# Altium schematic sheet Sensing_IC_Plus.SchDoc(Sensing_IC_Plus)
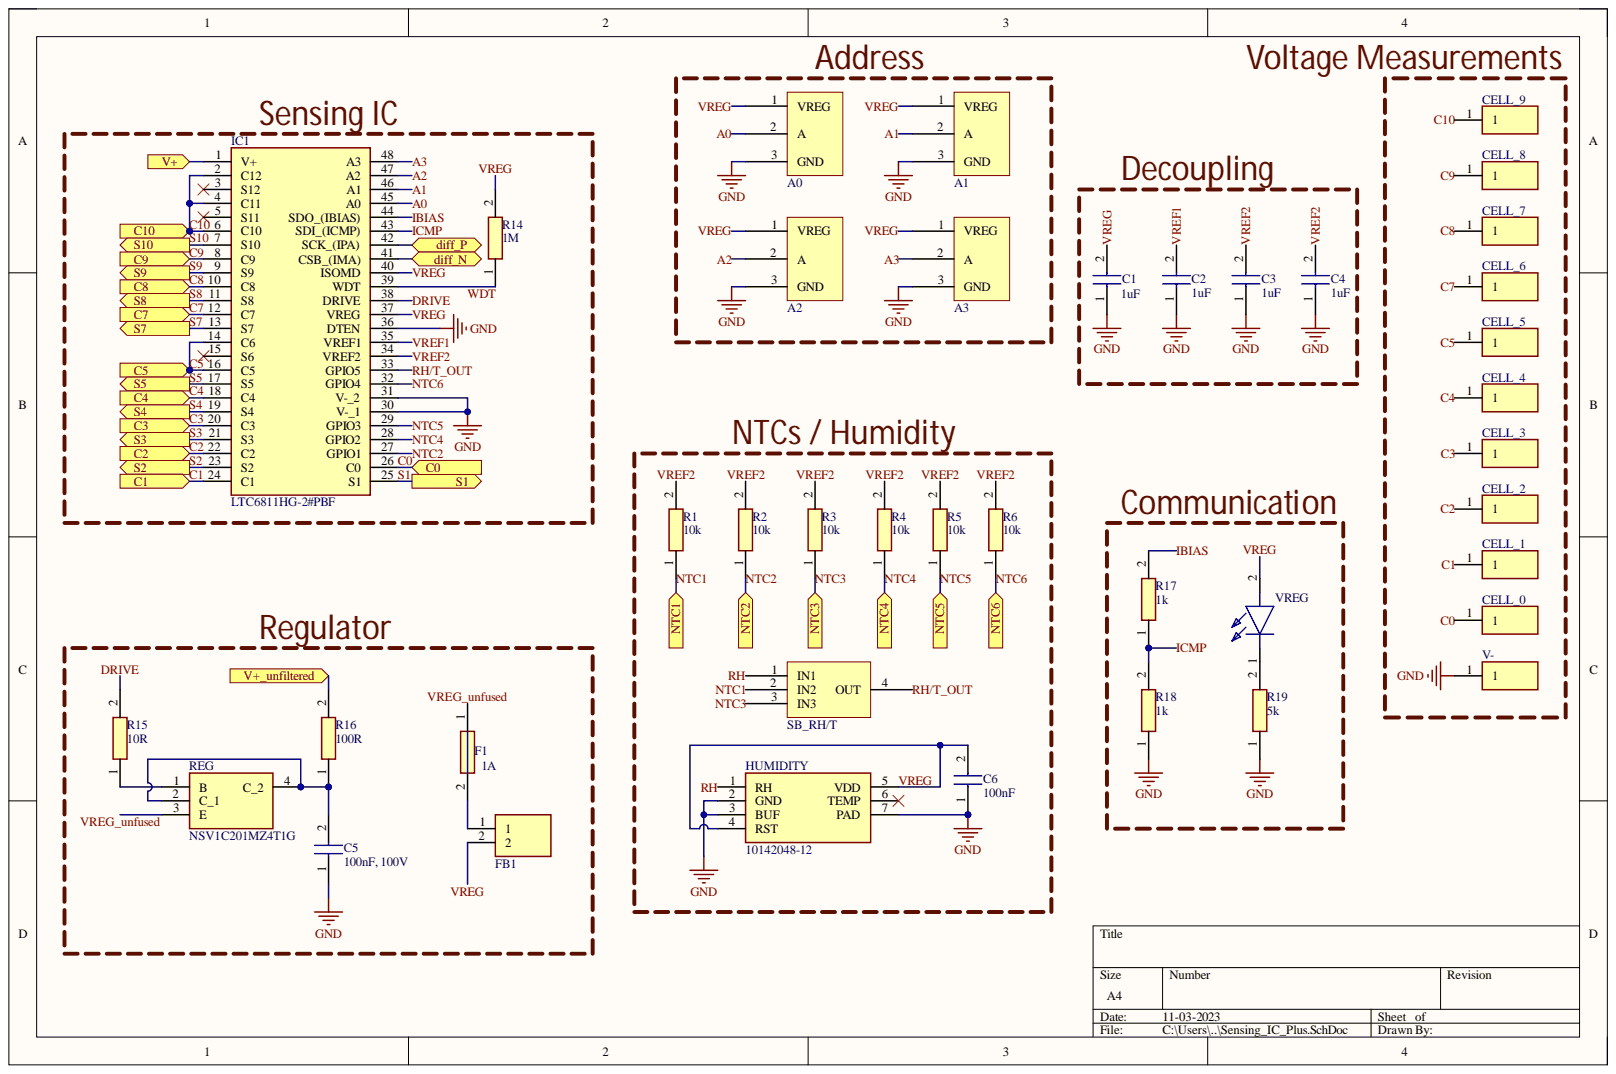
[diagram p0: Sensing_IC_Plus.SchDoc]

## Parts
*1  SB_RH/T  [RESC_1610X06N]  (part C)
A0  A0  [0R Resistor 0402]  (part D)
A1  A1  [0R Resistor 0402]  (part D)
A2  A2  [0R Resistor 0402]  (part D)
A3  A3  [0R Resistor 0402]  (part D)
C1  1uF  [CAPC1005X56N]
C2  1uF  [CAPC1005X56N]
C3  1uF  [CAPC1608X90N]
C4  1uF  [CAPC1608X90N]
C5  100nF, 100V  [CAPC1608X90N]
C6  100nF  [CAPC1608X90N]
CELL_0  050_LT_B2  [050LTB2]  (value not drawn)
CELL_1  050_LT_B2  [050LTB2]  (value not drawn)
CELL_2  050_LT_B2  [050LTB2]  (value not drawn)
CELL_3  050_LT_B2  [050LTB2]  (value not drawn)
CELL_4  050_LT_B2  [050LTB2]  (value not drawn)
CELL_5  050_LT_B2  [050LTB2]  (value not drawn)
CELL_6  050_LT_B2  [050LTB2]  (value not drawn)
CELL_7  050_LT_B2  [050LTB2]  (value not drawn)
CELL_8  050_LT_B2  [050LTB2]  (value not drawn)
CELL_9  050_LT_B2  [050LTB2]  (value not drawn)
F1  1A  [FUSC1608X30N]
FB1  MMZ1608SHE102ATD25  [BEADC1608X95N]  (value not drawn)
HUMIDITY  10142048-12  [1014204812]
IC1  LTC6811HG-2#PBF  [SOP50P780X200-48N]
R1  10k  [RESC1608X55N]
R2  10k  [RESC1608X55N]
R3  10k  [RESC1608X55N]
R4  10k  [RESC1608X55N]
R5  10k  [RESC1608X55N]
R6  10k  [RESC1608X55N]
R14  1M  [RESC1005X35N]
R15  10R  [RESC1608X55N]
R16  100R  [RESC1608X55N]
R17  1k  [RESC1608X55N]
R18  1k  [RESC1608X55N]
R19  5k  [RESC1608X55N]
REG  NSV1C201MZ4T1G  [SOT230P700X175-4N]
V-  050_LT_B2  [050LTB2]  (value not drawn)
VREG  LTST-C170KRKT  [LEDC2012X120N]  (value not drawn)

## Ports
C0, C1, C2, C3, C4, C5, C7, C8, C9, C10, diff_N, diff_P, NTC1, NTC2, NTC3, NTC4, NTC5, NTC6, S1, S2, S3, S4, S5, S7, S8, S9, S10, V+, V+_unfiltered

## Nets
GND: A0.3(GND) A1.3(GND) A2.3(GND) A3.3(GND) C1.1 C2.1 C3.1 C4.1 C5.1 C6.1 HUMIDITY.2(GND) HUMIDITY.3(BUF) HUMIDITY.7(PAD) IC1.30(V-_1) IC1.31(V-_2) IC1.36(DTEN) R18.1 R19.1 V-.1
A0: A0.2(A) IC1.45(A0)
A1: A1.2(A) IC1.46(A1)
A2: A2.2(A) IC1.47(A2)
A3: A3.2(A) IC1.48(A3)
C0: CELL_0.1 IC1.26(C0) ; port C0
C1: CELL_1.1 IC1.24(C1) ; port C1
C2: CELL_2.1 IC1.22(C2) ; port C2
C3: CELL_3.1 IC1.20(C3) ; port C3
C4: CELL_4.1 IC1.18(C4) ; port C4
C5: CELL_5.1 IC1.14(C6) IC1.16(C5) ; port C5
C7: CELL_6.1 IC1.12(C7) ; port C7
C8: CELL_7.1 IC1.10(C8) ; port C8
C9: CELL_8.1 IC1.8(C9) ; port C9
C10: CELL_9.1 IC1.2(C12) IC1.4(C11) IC1.6(C10) ; port C10
diff_N: IC1.41(CSB_(IMA)) ; port diff_N
diff_P: IC1.42(SCK_(IPA)) ; port diff_P
DRIVE: IC1.38(DRIVE) R15.2
IBIAS: IC1.44(SDO_(IBIAS)) R17.2
ICMP: IC1.43(SDI_(ICMP)) R17.1 R18.2
NTC1: *1.2(IN2) R1.1 ; port NTC1
NTC2: IC1.27(GPIO1) R2.1 ; port NTC2
NTC3: *1.3(IN3) R3.1 ; port NTC3
NTC4: IC1.28(GPIO2) R4.1 ; port NTC4
NTC5: IC1.29(GPIO3) R5.1 ; port NTC5
NTC6: IC1.32(GPIO4) R6.1 ; port NTC6
RH: *1.1(IN1) HUMIDITY.1(RH)
RH/T_OUT: *1.4(OUT) IC1.33(GPIO5)
S1: IC1.25(S1) ; port S1
S2: IC1.23(S2) ; port S2
S3: IC1.21(S3) ; port S3
S4: IC1.19(S4) ; port S4
S5: IC1.17(S5) ; port S5
S7: IC1.13(S7) ; port S7
S8: IC1.11(S8) ; port S8
S9: IC1.9(S9) ; port S9
S10: IC1.7(S10) ; port S10
V+: IC1.1(V+) ; port V+
V+_unfiltered: R16.2 ; port V+_unfiltered
VREF1: C2.2 IC1.35(VREF1)
VREF2: C3.2 C4.2 IC1.34(VREF2) R1.2 R2.2 R3.2 R4.2 R5.2 R6.2
VREG: A0.1(VREG) A1.1(VREG) A2.1(VREG) A3.1(VREG) C1.2 C6.2 FB1.2 HUMIDITY.4(RST) HUMIDITY.5(VDD) IC1.37(VREG) IC1.40(ISOMD) R14.2 VREG.2
VREG_unfused: F1.1 REG.3(E)
NetC5_2: C5.2 R16.1 REG.2(C_1) REG.4(C_2)
NetF1_2: F1.2 FB1.1
NetIC1_39: IC1.39(WDT) R14.1
NetR15_1: R15.1 REG.1(B)
NetR19_2: R19.2 VREG.1
WDT:

Not connected: HUMIDITY 6(TEMP); IC1 3(S12) 5(S11) 15(S6)

## Library data of the parts
A0-A3 = ADDRESS: Component Kind: Standard; Library Name: BMS_CustomLib.SchLib
C1-C6 = 06035C101K4T2A: Capacitor; Manufacturer_Name: AVX; Component Kind: Standard; Datasheet Link: https://componentsearchengine.com/Datasheets/1/06031A100FAT2A.pdf; Height: 0.9mm; Manufacturer_Part_Number: 06035C101K4T2A; Mouser Part Number: 581-06035C101K4T2A; Mouser Price/Stock: https://www.mouser.co.uk/ProductDetail/AVX/06035C101K4T2A/?qs=%252Bwax1qqoKK9kpvNfpp2NFA%3D%3D
CELL_0-CELL_9 V- = 050_LT_B2: Connector; Manufacturer_Name: Oxley; Manufacturer_Part_Number: 050/LT/B2; Component Kind: Standard; Datasheet Link: http://www.farnell.com/datasheets/2644146.pdf?_ga=2.63921641.795442872.1562935128-2061028581.1560430415; Height: 4.5mm; Library Name: BMS_Slave.SchLib
F1 = 0494.500: Fuse; Manufacturer_Name: LITTELFUSE; Component Kind: Standard; Datasheet Link: https://datasheet.datasheetarchive.com/originals/dk/DKDS41/DSANUWW0025996.pdf; Height: 0.305mm; Manufacturer_Part_Number: 0494.500
FB1 = MMZ1608SHE102ATD25: Ferrite Bead; Manufacturer_Name: TDK; Component Kind: Standard; Datasheet Link: https://product.tdk.com/system/files/dam/doc/product/emc/emc/beads/catalog/beads_automotive_signal_mmz1608-he_en.pdf; Height: 0.95mm; Library Name: BMS_schlib.SchLib; Manufacturer_Part_Number: MMZ1608SHE102ATD25; Mouser Part Number: 810-MMZ1608SHE102ATD; Mouser Price/Stock: https://www.mouser.co.uk/ProductDetail/TDK/MMZ1608SHE102ATD25?qs=Jslch3jnSjlR%2F2OQ%252B8tYFg%3D%3D
HUMIDITY = 10142048-12: Integrated Circuit; Manufacturer_Name: TE Connectivity; Component Kind: Standard; Height: 0.95mm; Manufacturer_Part_Number: 10142048-12; Mouser Part Number: 824-10142048-12; Mouser Price/Stock: https://www.mouser.co.uk/ProductDetail/Measurement-Specialties/10142048-12?qs=GedFDFLaBXHtvRuUiHIvlg%3D%3D
IC1 = LTC6811HG-2#PBF: Integrated Circuit; Manufacturer_Name: Analog Devices; Arrow Part Number: LTC6811HG-2#PBF; Arrow Price/Stock: https://www.arrow.com/en/products/ltc6811hg-2pbf/analog-devices?region=nac; Component Kind: Standard; Datasheet Link: https://datasheet.datasheetarchive.com/originals/distributors/Datasheets_SAMA/7a3f6461edc579e3637f6c847820bfef.pdf; Height: 2mm; Manufacturer_Part_Number: LTC6811HG-2#PBF; Mouser Part Number: 584-LTC6811HG-2#PBF; Mouser Price/Stock: https://www.mouser.co.uk/ProductDetail/Analog-Devices/LTC6811HG-2PBF?qs=oahfZPh6IAIAIIgZWv9WxQ%3D%3D
R1-R6 R14-R19 = CR0603-J_-9R1ELF: 0603 Resistor; Manufacturer_Name: Bourns; Manufacturer_Part_Number: CR0603-J/-9R1ELF; Component Kind: Standard; Datasheet Link: https://www.bourns.com/pdfs/chpreztr.pdf; Height: 0.55mm; Mouser Part Number: 652-CR0603-J/-9R1ELF; Mouser Price/Stock: https://www.mouser.co.uk/ProductDetail/Bourns/CR0603-J-9R1ELF?qs=2as47fUcDMQnJV7FLitZAw%3D%3D
REG = NSV1C201MZ4T1G: Transistor; Manufacturer_Name: onsemi; Arrow Part Number: NSV1C201MZ4T1G; Arrow Price/Stock: https://www.arrow.com/en/products/nsv1c201mz4t1g/on-semiconductor; Component Kind: Standard; Datasheet Link: http://www.onsemi.com/pub/Collateral/NSS1C201MZ4-D.PDF; Height: 1.75mm; Manufacturer_Part_Number: NSV1C201MZ4T1G; Mouser Part Number: 863-NSV1C201MZ4T1G; Mouser Price/Stock: https://www.mouser.co.uk/ProductDetail/onsemi/NSV1C201MZ4T1G?qs=abmNkq9no6CNkkQTLXtvJQ%3D%3D
VREG = LTST-C170KRKT: LED; Manufacturer_Name: Lite-On; Arrow Part Number: LTST-C170KRKT; Arrow Price/Stock: https://www.arrow.com/en/products/ltst-c170krkt/lite-on-technology?region=nac; Component Kind: Standard; Datasheet Link: https://componentsearchengine.com/Datasheets/1/LTST-C170KRKT.pdf; Height: 1.2mm; Library Name: BMS_schlib.SchLib; Manufacturer_Part_Number: LTST-C170KRKT; Mouser Part Number: 859-LTST-C170KRKT; Mouser Price/Stock: https://www.mouser.co.uk/ProductDetail/Lite-On/LTST-C170KRKT?qs=NUb82WqeCyrVOID%2Fxt4rgA%3D%3D
*1 = SB_RH/T: Component Kind: Standard; Library Name: BMS_CustomLib.SchLib
